# Altium schematic sheet 1-Top.SchDoc(1-Top)
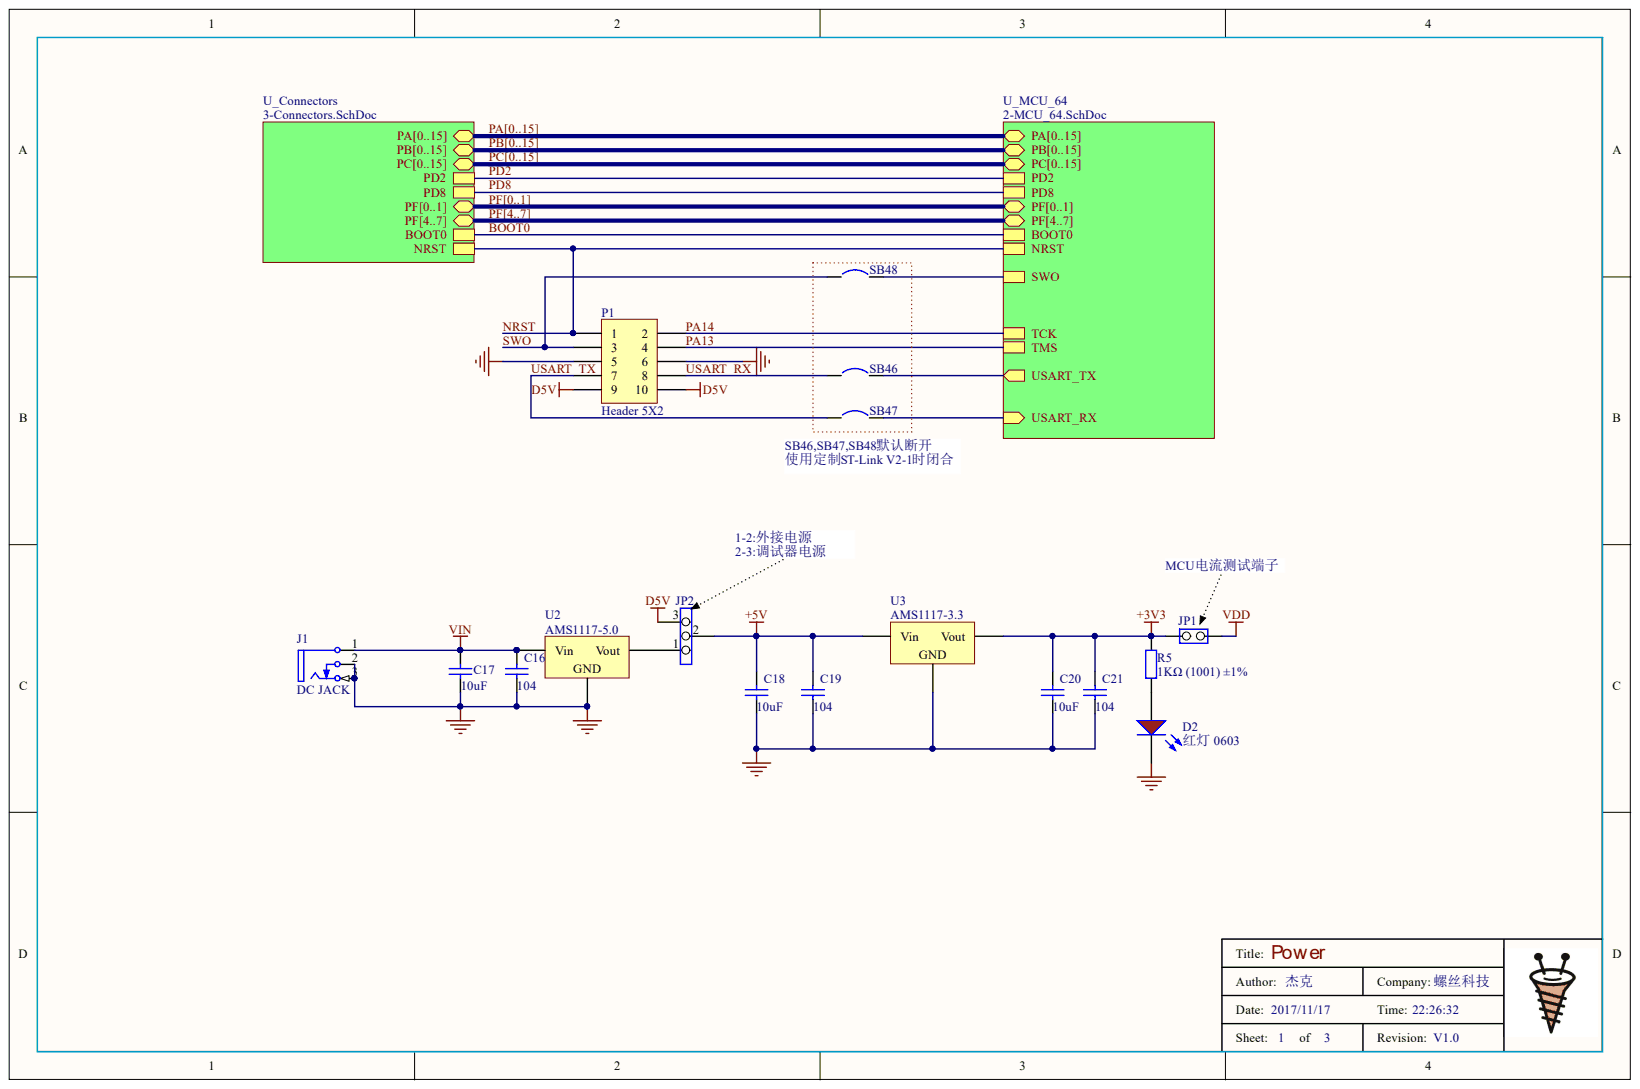
[diagram p0: 1-Top.SchDoc]

## Parts
C16  value 104  [0603_C]  (hidden comment: 100nF (104) 10% 50V)
C17  value 10uF  [1206_C]  (hidden comment: 10uF (106) 10% 50V)
C18  value 10uF  [1206_C]  (hidden comment: 10uF (106) 10% 50V)
C19  value 104  [0603_C]  (hidden comment: 100nF (104) 10% 50V)
C20  value 10uF  [1206_C]  (hidden comment: 10uF (106) 10% 50V)
C21  value 104  [0603_C]  (hidden comment: 100nF (104) 10% 50V)
D2  红灯 0603  [0603_LED_S1]
J1  DC JACK  [DC-JACK-SMT]
JP1  (no value)  [SIP2-2.54mm]
JP2  (no value)  [SIP3-2.54mm]
P1  Header 5X2  [JTAG10P2.54L - 3D]
R5  1KΩ (1001) ±1%  [0603_R]
SB46  Circuit Breaker  [JP_SMD]  (value not drawn)
SB47  Circuit Breaker  [JP_SMD]  (value not drawn)
SB48  Circuit Breaker  [JP_SMD]  (value not drawn)
U2  AMS1117-5.0  [SOT-223]
U3  AMS1117-3.3  [SOT-223]

## Nets
+3V3: C20.1 C21.1 JP1.1 R5.2 U3.2
+5V: C18.1 C19.1 JP2.2 U3.3
D5V: JP2.3 P1.9 P1.10
GND: C16.2 C17.2 C18.2 C19.2 C20.2 C21.2 D2.K J1.2 J1.3 P1.5 P1.6 U2.1 U3.1
VDD: JP1.2
VIN: C16.1 C17.1 J1.1 U2.3
NRST: P1.1
SWCLK: P1.2
SWDIO: P1.4
SWO: P1.3 SB48.1
USART_RX: P1.8 SB46.1
USART_TX: P1.7 SB47.1
NetD2_A: D2.A R5.1
NetJP2_1: JP2.1 U2.2
NetSB46_2: SB46.2
NetSB47_2: SB47.2
NetSB48_2: SB48.2
BOOT0:
PA0:
PA1:
PA2:
PA3:
PA4:
PA5:
PA6:
PA7:
PA8:
PA9:
PA10:
PA11:
PA12:
PA13:
PA14:
PA15:
PB0:
PB1:
PB2:
PB3:
PB4:
PB5:
PB6:
PB7:
PB8:
PB9:
PB10:
PB11:
PB12:
PB13:
PB14:
PB15:
PC0:
PC1:
PC2:
PC3:
PC4:
PC5:
PC6:
PC7:
PC8:
PC9:
PC10:
PC11:
PC12:
PC13:
PC14:
PC15:
PD2:
PD8:
PF0:
PF1:
PF4:
PF5:
PF6:
PF7:

## Library data of the parts
C16 C19 C21 = 0603 100nF (104) 10% 50V: 贴片电容; Component Kind: Standard; ComponentLink1Description: 供应商链接; ComponentLink1URL: http://www.szlcsc.com/product/details_15331.html; Library Name: Miscellaneous Devices LC.IntLib; Package: 0603; Supplier: LC; SuppliersPartNumber: C14663
C17 C18 C20 = 1206 10uF (106) 10% 50V: 贴片电容; Component Kind: Standard; ComponentLink1Description: 供应商链接; ComponentLink1URL: http://www.szlcsc.com/product/details_14236.html; Library Name: Miscellaneous Devices LC.IntLib; Package: 1206; Supplier: LC; SuppliersPartNumber: C13585
D2 = 0603 红灯: 发光二极管; Component Kind: Standard; ComponentLink1Description: 供应商链接; ComponentLink1URL: http://www.szlcsc.com/product/details_2659.html; Library Name: Miscellaneous Devices LC.IntLib; Package: 0603; Supplier: LC; SuppliersPartNumber: C2286
J1 = Phonejack2 TN: Jack Socket, 1/4" [6.5mm], Thru-Hole, Vertical, 2-Conductor with Tip closed; DrillAndSlotSize: Corresponds to nominal value.; PackageDocument: 2003; PCB_Layout: Complies with manufacturer's recommendation.; Component Kind: Standard; ComponentLink1Description: Manufacturer Link; ComponentLink1URL: http://www.cliffuk.co.uk/; DatasheetDocument: 2003; LatestRevisionDate: 15-Jul-2004; LatestRevisionNote: Component Replaced & renamed from "Phonejack".; Library Name: Miscellaneous Connectors.IntLib; PackageReference: S1VS_SNB; Publisher: Altium Limited
JP1 = JP2: Component Kind: Standard
JP2 = Jumper3: Component Kind: Standard; Library Name: STR910_SCHLIB.SCHLIB
P1 = Header 5X2: Header, 5-Pin, Dual row; Component Kind: Standard; LatestRevisionDate: 17-Jul-2002; LatestRevisionNote: Re-released for DXP Platform.; Library Name: Miscellaneous Connectors.IntLib; Publisher: Altium Limited
R5 = 0603 1KΩ (1001) 1%: 贴片电阻; Component Kind: Standard; ComponentLink1Description: 供应商链接; ComponentLink1URL: http://www.szlcsc.com/product/details_21904.html; Library Name: Miscellaneous Devices LC.IntLib; Package: 0603; Supplier: LC; SuppliersPartNumber: C21190
SB46-SB48 = Circuit Breaker: Solder: NO; Component Kind: Standard; Description: Circuit Breaker; Library Name: MCD_TOOLS.SCHLIB; Published: 24-Mar-1999; Revision: July-2002: Re-released for DXP Platform.; Publisher: Altium Limited
U2 = SOT-223 AMS1117-5.0: 低压差线性稳压(LDO); Component Kind: Standard; ComponentLink1Description: 供应商链接; ComponentLink1URL: http://www.szlcsc.com/product/details_6653.html; Library Name: Miscellaneous Devices LC.IntLib; Package: SOT-223; Supplier: LC; SuppliersPartNumber: C6187
U3 = SOT-223 AMS1117-3.3: 低压差线性稳压(LDO); Component Kind: Standard; ComponentLink1Description: 供应商链接; ComponentLink1URL: http://www.szlcsc.com/product/details_6652.html; Library Name: Miscellaneous Devices LC.IntLib; Package: SOT-223; Supplier: LC; SuppliersPartNumber: C6186
